# Altium schematic sheet SolarPowerBase_Sheet_1.SchDoc
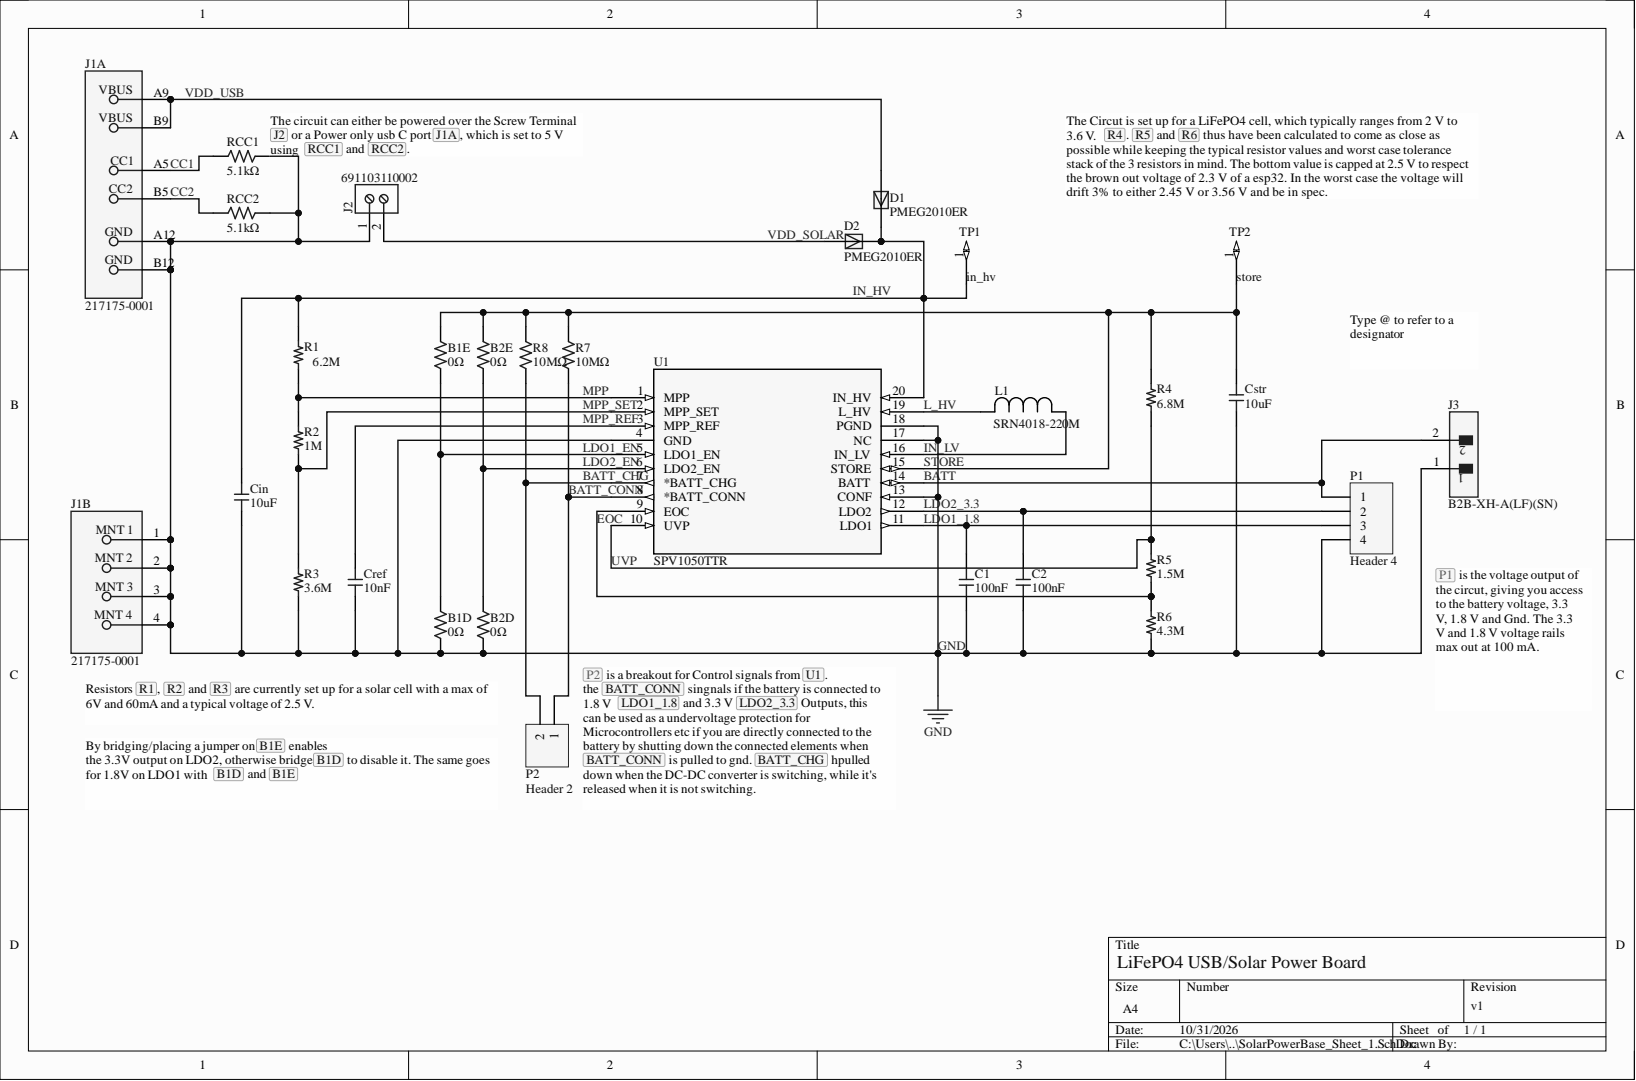
[diagram p0: SolarPowerBase_Sheet_1.SchDoc]

## Parts
B1D  Resistance: 0Ω  [RES-0402]  (hidden comment: 0402WGF0000TCE)
B1E  Resistance: 0Ω  [RES-0402]  (hidden comment: 0402WGF0000TCE)
B2D  Resistance: 0Ω  [RES-0402]  (hidden comment: 0402WGF0000TCE)
B2E  Resistance: 0Ω  [RES-0402]  (hidden comment: 0402WGF0000TCE)
C1  Capacitance: 100nF  [CAP-0402]  (hidden comment: CL05B104KB54PNC)
C2  Capacitance: 100nF  [CAP-0402]  (hidden comment: CL05B104KB54PNC)
Cin  Capacitance: 10uF  [CAP-0603]  (hidden comment: CL10A106KP8NNNC)
Cref  Capacitance: 10nF  [CAP-0402]  (hidden comment: CL05B103KB5NNNC)
Cstr  Capacitance: 10uF  [CAP-0603]  (hidden comment: CL10A106KP8NNNC)
D1  PMEG2010ER  [SODFL3517X110N]
D2  PMEG2010ER  [SODFL3517X110N]
J1  217175-0001  [FP-217175-0001-MFG]  (parts A,B)
J2  691103110002  [691103110002]
J3  B2B-XH-A(LF)(SN)  [FP-B2B-XH-A_LF_SN-MFG]
L1  SRN4018-220M  [IND_BOURNS_SRN4018]
P1  Header 4  [HDR1X4]
P2  Header 2  [HDR1X2]
R1  6.2M  [RES-0805-HS]
R2  1M  [RES-0805-HS]
R3  value 3.6M  [RES-0805-HS]  (hidden comment: 2.7k)
R4  6.8M  [RES-0805-HS]
R5  1.5M  [RES-0805-HS]
R6  4.3M  [RES-0805-HS]
R7  Resistance: 10MΩ  [RES-0603]  (hidden comment: 0603WAF1005T5E)
R8  Resistance: 10MΩ  [RES-0603]  (hidden comment: 0603WAF1005T5E)
RCC1  Resistance: 5.1kΩ  [RES-0402]  (hidden comment: 0402WGF5101TCE)
RCC2  Resistance: 5.1kΩ  [RES-0402]  (hidden comment: 0402WGF5101TCE)
TP1  in_hv  [Pogopin_pad_female_2mm]  (no BOM)
TP2  store  [Pogopin_pad_female_2mm]  (no BOM)
U1  SPV1050TTR  [VFQFPN20_3X3_STM]

## Nets
BATT: J3.2 P1.1 U1.14(BATT)
BATT_CHG: P2.2 R8.1 U1.7(*BATT_CHG)
BATT_CONN: P2.1 R7.1 U1.8(*BATT_CONN)
CC1: J1.A5 RCC1.1
CC2: J1.B5 RCC2.1
EOC: R5.1 R6.2 U1.9(EOC)
GND: B1D.1 B2D.1 C1.1 C2.1 Cin.1 Cref.1 Cstr.1 J1.1 J1.2 J1.3 J1.4 J1.A12 J1.B12 J2.1 J3.1 P1.4 R3.1 R6.1 RCC1.2 RCC2.2 U1.4(GND) U1.13(CONF) U1.17(NC) U1.18(PGND)
IN_HV: Cin.2 D1.C D2.C R1.2 TP1.1 U1.20(IN_HV)
IN_LV: L1.1 U1.16(IN_LV)
L_HV: L1.2 U1.19(L_HV)
LDO1_1.8: C1.2 P1.3 U1.11(LDO1)
LDO1_EN: B1D.2 B1E.1 U1.5(LDO1_EN)
LDO2_3.3: C2.2 P1.2 U1.12(LDO2)
LDO2_EN: B2D.2 B2E.1 U1.6(LDO2_EN)
MPP: R1.1 R2.2 U1.1(MPP)
MPP_REF: Cref.2 U1.3(MPP_REF)
MPP_SET: R2.1 R3.2 U1.2(MPP_SET)
STORE: B1E.2 B2E.2 Cstr.2 R4.2 R7.2 R8.2 TP2.1 U1.15(STORE)
UVP: R4.1 R5.2 U1.10(UVP)
VDD_SOLAR: D2.A J2.2
VDD_USB: D1.A J1.A9 J1.B9

## Library data of the parts
B1D B1E B2D B2E = 0402WGF0000TCE: Resistor, 0Ω, ±1%, 62.5mW, 50V, ±800ppm/℃, -55℃~+155℃, SMD 0402; Manufacturer: Uniroyal; Category: Resistors; Component Kind: Standard; ComponentLink1Description: Datasheet; ComponentLink1URL: https://wmsc.lcsc.com/wmsc/upload/file/pdf/v2/lcsc/2206010216_UNI-ROYAL-Uniroyal-Elec-0402WGF0000TCE_C17168.pdf; ComponentLink2Description: JLCPCB Part URL; ComponentLink2URL: https://jlcpcb.com/partdetail/C17168; JLCPCB Basic Part: Yes; JLCPCB Part Number: C17168; Library Name: jlcpcb_2025_04_resistors_basic.schlib; Manufacturer Part Number: 0402WGF0000TCE; Package Size: 0402; Power Rating: 62.5mW; Supplier 1: LCSC; Supplier Part Number 1: C17168; Temperature Coefficient: ±800ppm/℃; Temperature Rating: -55℃~+155℃; Tolerance: ±1%; Voltage Rating: 50V
C1 C2 = CL05B104KB54PNC: Capacitor, Ceramic (MLCC), 100nF, ±10%, 50V, X7R, ±10%, SMD 0402; Manufacturer: Samsung Electro-Mechanics; Capacitance @ 1.2V DC Bias: 105.639nF; Capacitance @ 1.5V DC Bias: 105.528nF; Capacitance @ 1.8V DC Bias: 105.317nF; Capacitance @ 1.35V DC Bias: 105.594nF; Capacitance @ 1V DC Bias: 105.687nF; Capacitance @ 2.5V DC Bias: 104.216nF; Capacitance @ 3.3V DC Bias: 102.385nF; Capacitance @ 3.7V DC Bias: 101.236nF; Capacitance @ 4.2V DC Bias: 99.650nF; Capacitance @ 5V DC Bias: 96.918nF; Capacitance @ 9V DC Bias: 81.073nF; Capacitance @ 12V DC Bias: 69.786nF; Capacitance @ 15V DC Bias: 59.475nF; Capacitance @ 24V DC Bias: 38.112nF; Capacitance @ 36V DC Bias: 23.027nF; Capacitance @ 48V DC Bias: 16.035nF; Impedance (0V Bias) @ 1GHz: 0.87Ω; Impedance (0V Bias) @ 1kHz: 1.7E+03Ω; Impedance (0V Bias) @ 1MHz: 1.84Ω; Impedance (0V Bias) @ 2GHz: 2Ω; Impedance (0V Bias) @ 5GHz: 3.96Ω; Impedance (0V Bias) @ 5kHz: 346Ω; Impedance (0V Bias) @ 5MHz: 0.366Ω; Impedance (0V Bias) @ 10kHz: 175Ω; Impedance (0V Bias) @ 10MHz: 0.172Ω; Impedance (0V Bias) @ 50kHz: 35.5Ω; Impedance (0V Bias) @ 50MHz: 0.0626Ω; Impedance (0V Bias) @ 100Hz: 1.66E+04Ω; Impedance (0V Bias) @ 100kHz: 17.9Ω; Impedance (0V Bias) @ 100MHz: 0.128Ω; Impedance (0V Bias) @ 500Hz: 3.38E+03Ω; Impedance (0V Bias) @ 500kHz: 3.64Ω; Impedance (0V Bias) @ 500MHz: 0.487Ω; Temperature Rating: -55°C ~ 125°C; Category: Capacitors - MLCC; Component Kind: Standard; ComponentLink1Description: Datasheet; ComponentLink1URL: https://wmsc.lcsc.com/wmsc/upload/file/pdf/v2/lcsc/2304140030_Samsung-Electro-Mechanics-CL05B104KB54PNC_C307331.pdf; ComponentLink2Description: JLCPCB Part URL; ComponentLink2URL: https://jlcpcb.com/partdetail/C307331; ComponentLink3Description: LCSC Part URL; ComponentLink3URL: https://lcsc.com/product-detail/Multilayer-Ceramic-Capacitors-MLCC---SMD-SMT_Samsung-Electro-Mechanics-Samsung-Electro-Mechanics-CL05B104KB54PNC_C307331.html; ComponentLink4Description: Samsung Component Library; ComponentLink4URL: https://weblib.samsungsem.com/mlcc/mlcc-ec.do?partNumber=CL05B104KB54PN; JLCPCB Basic Part: Yes; JLCPCB Part Number: C307331; Library Name: jlcpcb_2025_04_capacitors_basic.schlib; Manufacturer Part Number: CL05B104KB54PNC; Package Size: 0402; RoHS: Yes; Supplier 1: LCSC; Supplier Part Number 1: C307331; Temperature Coefficient: X7R; Tolerance: ±10%; Voltage Rating: 50V
Cin Cstr = CL10A106KP8NNNC: Capacitor, Ceramic (MLCC), 10uF, ±10%, 10V, X5R, ±10%, SMD 0603; Manufacturer: Samsung Electro-Mechanics; Capacitance @ 1.2V DC Bias: 8.084uF; Capacitance @ 1.5V DC Bias: 7.497uF; Capacitance @ 1.8V DC Bias: 6.915uF; Capacitance @ 1.35V DC Bias: 7.793uF; Capacitance @ 1V DC Bias: 8.451uF; Capacitance @ 2.5V DC Bias: 5.691uF; Capacitance @ 3.3V DC Bias: 4.464uF; Capacitance @ 3.7V DC Bias: 3.996uF; Capacitance @ 4.2V DC Bias: 3.516uF; Capacitance @ 5V DC Bias: 2.897uF; Capacitance @ 9V DC Bias: 1.372uF; Impedance (0V Bias) @ 1GHz: 0.788Ω; Impedance (0V Bias) @ 1kHz: 22.6Ω; Impedance (0V Bias) @ 1MHz: 0.0217Ω; Impedance (0V Bias) @ 2GHz: 1.8Ω; Impedance (0V Bias) @ 5GHz: 3.33Ω; Impedance (0V Bias) @ 5kHz: 4.64Ω; Impedance (0V Bias) @ 5MHz: 0.0144Ω; Impedance (0V Bias) @ 10kHz: 2.35Ω; Impedance (0V Bias) @ 10MHz: 0.0227Ω; Impedance (0V Bias) @ 50kHz: 0.481Ω; Impedance (0V Bias) @ 50MHz: 0.0729Ω; Impedance (0V Bias) @ 100Hz: 217Ω; Impedance (0V Bias) @ 100kHz: 0.243Ω; Impedance (0V Bias) @ 100MHz: 0.123Ω; Impedance (0V Bias) @ 500Hz: 44.6Ω; Impedance (0V Bias) @ 500kHz: 0.0484Ω; Impedance (0V Bias) @ 500MHz: 0.439Ω; Impedance (10V Bias) @ 1GHz: 0.786Ω; Impedance (10V Bias) @ 1kHz: 162Ω; Impedance (10V Bias) @ 1MHz: 0.161Ω; Impedance (10V Bias) @ 2GHz: 1.77Ω; Impedance (10V Bias) @ 5GHz: 3.28Ω; Impedance (10V Bias) @ 5kHz: 32.7Ω; Impedance (10V Bias) @ 5MHz: 0.0215Ω; Impedance (10V Bias) @ 10kHz: 16.4Ω; Impedance (10V Bias) @ 10MHz: 0.0228Ω; Impedance (10V Bias) @ 50kHz: 3.3Ω; Impedance (10V Bias) @ 50MHz: 0.0759Ω; Impedance (10V Bias) @ 100Hz: 1.6E+03Ω; Impedance (10V Bias) @ 100kHz: 1.66Ω; Impedance (10V Bias) @ 500Hz: 323Ω; Impedance (10V Bias) @ 500kHz: 0.331Ω; Impedance (10V Bias) @ 500MHz: 0.443Ω; Temperature Rating: -55°C ~ 85°C; Category: Capacitors - MLCC; Component Kind: Standard; ComponentLink1Description: Datasheet; ComponentLink1URL: https://wmsc.lcsc.com/wmsc/upload/file/pdf/v2/lcsc/2304140030_Samsung-Electro-Mechanics-CL10A106KP8NNNC_C19702.pdf; ComponentLink2Description: JLCPCB Part URL; ComponentLink2URL: https://jlcpcb.com/partdetail/C19702; ComponentLink3Description: LCSC Part URL; ComponentLink3URL: https://lcsc.com/product-detail/Multilayer-Ceramic-Capacitors-MLCC---SMD-SMT_Samsung-Electro-Mechanics-Samsung-Electro-Mechanics-CL10A106KP8NNNC_C19702.html; ComponentLink4Description: Samsung Component Library; ComponentLink4URL: https://weblib.samsungsem.com/mlcc/mlcc-ec.do?partNumber=CL10A106KP8NNN; Impedance (10V Bias) @ 100MHz: 0.123Ω; JLCPCB Basic Part: Yes; JLCPCB Part Number: C19702; Library Name: jlcpcb_2025_04_capacitors_basic.schlib; Manufacturer Part Number: CL10A106KP8NNNC; Package Size: 0603; RoHS: Yes; Supplier 1: LCSC; Supplier Part Number 1: C19702; Temperature Coefficient: X5R; Tolerance: ±10%; Voltage Rating: 10V
Cref = CL05B103KB5NNNC: Capacitor, Ceramic (MLCC), 10nF, ±10%, 50V, X7R, ±10%, SMD 0402; Manufacturer: Samsung Electro-Mechanics; Capacitance @ 1.2V DC Bias: 10.434nF; Capacitance @ 1.5V DC Bias: 10.455nF; Capacitance @ 1.8V DC Bias: 10.480nF; Capacitance @ 1.35V DC Bias: 10.444nF; Capacitance @ 1V DC Bias: 10.426nF; Capacitance @ 2.5V DC Bias: 10.514nF; Capacitance @ 3.3V DC Bias: 10.519nF; Capacitance @ 3.7V DC Bias: 10.504nF; Capacitance @ 4.2V DC Bias: 10.473nF; Capacitance @ 5V DC Bias: 10.402nF; Capacitance @ 9V DC Bias: 10.052nF; Capacitance @ 12V DC Bias: 9.639nF; Capacitance @ 15V DC Bias: 9.187nF; Capacitance @ 24V DC Bias: 7.606nF; Capacitance @ 36V DC Bias: 5.499nF; Capacitance @ 48V DC Bias: 3.591nF; Impedance (0V Bias) @ 1GHz: 1.42Ω; Impedance (0V Bias) @ 1kHz: 1.61E+04Ω; Impedance (0V Bias) @ 1MHz: 17.3Ω; Impedance (0V Bias) @ 2GHz: 3.22Ω; Impedance (0V Bias) @ 5GHz: 6.48Ω; Impedance (0V Bias) @ 5kHz: 3.27E+03Ω; Impedance (0V Bias) @ 5MHz: 3.52Ω; Impedance (0V Bias) @ 10kHz: 1.65E+03Ω; Impedance (0V Bias) @ 10MHz: 1.77Ω; Impedance (0V Bias) @ 50kHz: 335Ω; Impedance (0V Bias) @ 50MHz: 0.285Ω; Impedance (0V Bias) @ 100Hz: 1.57E+05Ω; Impedance (0V Bias) @ 100kHz: 169Ω; Impedance (0V Bias) @ 100MHz: 0.0835Ω; Impedance (0V Bias) @ 500Hz: 3.19E+04Ω; Impedance (0V Bias) @ 500kHz: 34.3Ω; Impedance (0V Bias) @ 500MHz: 0.751Ω; Temperature Rating: -55°C ~ 125°C; Category: Capacitors - MLCC; Component Kind: Standard; ComponentLink1Description: Datasheet; ComponentLink1URL: https://wmsc.lcsc.com/wmsc/upload/file/pdf/v2/lcsc/2304140030_Samsung-Electro-Mechanics-CL05B103KB5NNNC_C15195.pdf; ComponentLink2Description: JLCPCB Part URL; ComponentLink2URL: https://jlcpcb.com/partdetail/C15195; ComponentLink3Description: LCSC Part URL; ComponentLink3URL: https://lcsc.com/product-detail/Multilayer-Ceramic-Capacitors-MLCC---SMD-SMT_Samsung-Electro-Mechanics-Samsung-Electro-Mechanics-CL05B103KB5NNNC_C15195.html; ComponentLink4Description: Samsung Component Library; ComponentLink4URL: https://weblib.samsungsem.com/mlcc/mlcc-ec.do?partNumber=CL05B103KB5NNN; JLCPCB Basic Part: Yes; JLCPCB Part Number: C15195; Library Name: jlcpcb_2025_04_capacitors_basic.schlib; Manufacturer Part Number: CL05B103KB5NNNC; Package Size: 0402; RoHS: Yes; Supplier 1: LCSC; Supplier Part Number 1: C15195; Temperature Coefficient: X7R; Tolerance: ±10%; Voltage Rating: 50V
D1 D2 = PMEG2010ER: Rectifier Diode Schottky 1A Automotive 2-Pin SOD-123W T/R; MF: NXP Semiconductors; Check_prices: https://www.snapeda.com/parts/PMEG2010ER/NXP+Semiconductors/view-part/?ref=eda; Component Kind: Standard; Library Name: PMEG2010ER.IntLib; MP: PMEG2010ER; Package: SOD-123 Nexperia USA; SnapEDA_Link: https://www.snapeda.com/parts/PMEG2010ER/NXP+Semiconductors/view-part/?ref=snap
J1 = CMP-04767-000026-1: CONN RCP USB2.0 C 6+18P SMD RA; Component Kind: Standard; Library Name: Altium Content Vault
J2 = CMP-1502-03254-3: 1031 Series 3.5MM Pitch Straight, Pcb Terminal Block, Through Hole, 2 Way; Current: 10A; Number of Contacts: 2; Number of Levels: 1; Pitch: 3.5052mm; Plating: Steel; Stripping Length: -6mm; Voltage: 125V; Wire Gauge (Max): 26AWG; Wire Gauge (Min): 16AWG; Color: Blue; Component Kind: Standard; Contact Material: Copper, Nickel, Tin, Zinc; Contact Plating: Tin; Current Rating: 10A; Height: 8.5mm; Housing Material: Nylon; Lead Pitch: 3.5mm; Length: 7mm; Library Name: Altium Content Vault; Max Operating Temperature: 105°C; Min Operating Temperature: -40°C; Mount: Through Hole; Number of Pins: 2; Number of Positions Per Level: 2; Number of Rows: 1; Packaging: Box; RoHS: Compliant; Termination: Screw; Voltage Rating: 125V; Width: 8mm; Wire/Cable Gauge: 26AWG
J3 = CMP-2000-05888-3: CONN HEADER VERT 2POS 2.5MM; Connector Type: Male Pin; Contact Resistance: 20mR; Current Rating: 3A; Insulation Resistance: 1GR; Max Voltage Rating (AC): 250V; Number of Contacts: 2; Wire/Cable Gauge: 22AWG; Color: Natural; Component Kind: Standard; Contact Material: Brass; Contact Plating: Tin; Contact Type: Male Pin; Depth: 5.75mm; Fastening Type: Detent; Housing Color: White; Housing Material: Polyamide; Lead Free: Lead Free; Lead Pitch: 2.5mm; Length: 7.4mm; Library Name: Altium Content Vault; Max Current Rating: 3A; Max Operating Temperature: 85°C; Max Voltage Rating (DC): 250V; Min Operating Temperature: -25°C; Mount: Through Hole; Number of Positions: 2; Number of Rows: 1; Orientation: Straight; Packaging: Bulk; Pitch: 2.5mm; REACH SVHC: No SVHC; Series: XH; Termination: Solder; Voltage Rating: 250V; Voltage Rating (AC): 250V
L1 = SRN4018-220M: No Description Available; Manufacturer_Name: Bourns Electronics; Component Kind: Standard; Library Name: SRN4018-220M.SchLib; Manufacturer_Part_Number: SRN4018-220M; Copyright: Copyright (C) 2026 Ultra Librarian. All rights reserved.
P1 = Header 4: Header, 4-Pin; Component Kind: Standard; LatestRevisionDate: 17-Jul-2002; LatestRevisionNote: Re-released for DXP Platform.; Library Name: Miscellaneous Connectors.IntLib; Signal Integrity: Connector; Publisher: Altium Limited
P2 = Header 2: Header, 2-Pin; Component Kind: Standard; LatestRevisionDate: 17-Jul-2002; LatestRevisionNote: Re-released for DXP Platform.; Library Name: Miscellaneous Connectors.IntLib; Signal Integrity: Connector; Publisher: Altium Limited
R1 = CRCW08056M20FKEA: RES SMD 6.2M OHM 1% 1/8W 0805; Manufacturer: Vishay Dale; Database Table Name: Resistors - Surface Mount - 0805; Power (Watts): 0.125W, 1/8W; Resistance: 6.2 MOhms; Temperature Coefficient: ±100ppm/°C; Component Kind: Standard; ComponentLink1Description: Datasheet; ComponentLink1URL: http://www.vishay.com/docs/20035/dcrcwe3.pdf; ComponentLink2Description: DigiKey Link; ComponentLink2URL: https://www.digikey.com/en/products/detail/vishay-dale/CRCW08056M20FKEA/1176107; Composition: Thick Film; Device Package: 0805 (2012 Metric); Features: Automotive AEC-Q200; Height - Seated (Max): 0.020" (0.50mm); LastUpdated: 05/04/2026 08:09:23 pm; Library Name: Celestial Altium Library - altium_library.DbLib; Lifecycle Status: Active; Minimum Order: 1; Number of Terminations: 2; Operating Temperature: -55°C ~ 155°C; Package / Case: 0805 (2012 Metric); Packaging: TapeAndReel; Part Number: CRCW08056M20FKEA; Part Status: Active; PartId: 49587; Price: 0.01; Ratings: AEC-Q200; Series: D/CRCW e3; Size / Dimension: 0.079" L x 0.049" W (2.00mm x 1.25mm); Supplier 1: DigiKey; Supplier Device Package: 0805; Supplier Part Number 1: 541-6.20MCCT-ND; Tolerance: ±1%; Value: 6.2M
R2 = CRCW08051M00FKEA: RES SMD 1M OHM 1% 1/8W 0805; Manufacturer: Vishay Dale; Database Table Name: Resistors - Surface Mount - 0805; Power (Watts): 0.125W, 1/8W; Resistance: 1 MOhms; Temperature Coefficient: ±100ppm/°C; Component Kind: Standard; ComponentLink1Description: Datasheet; ComponentLink1URL: http://www.vishay.com/docs/20035/dcrcwe3.pdf; ComponentLink2Description: DigiKey Link; ComponentLink2URL: https://www.digikey.com/en/products/detail/vishay-dale/CRCW08051M00FKEA/1176016; Composition: Thick Film; Device Package: 0805 (2012 Metric); Features: Automotive AEC-Q200; Height - Seated (Max): 0.020" (0.50mm); LastUpdated: 05/04/2026 08:11:05 pm; Library Name: Celestial Altium Library - altium_library.DbLib; Lifecycle Status: Active; Minimum Order: 1; Number of Terminations: 2; Operating Temperature: -55°C ~ 155°C; Package / Case: 0805 (2012 Metric); Packaging: TapeAndReel; Part Number: CRCW08051M00FKEA; Part Status: Active; PartId: 32627; Price: 0.01; Ratings: AEC-Q200; Series: D/CRCW e3; Size / Dimension: 0.079" L x 0.049" W (2.00mm x 1.25mm); Supplier 1: DigiKey; Supplier Device Package: 0805; Supplier Part Number 1: 541-1.00MCCT-ND; Tolerance: ±1%; Value: 1M
R3 = CRCW08053M60FKEA: RES SMD 3.6M OHM 1% 1/4W 0805; Manufacturer: Vishay Dale; Database Table Name: Resistors - Surface Mount - 0805; Power (Watts): 0.25W, 1/4W; Resistance: 3.6 MOhms; Temperature Coefficient: ±100ppm/°C; Component Kind: Standard; ComponentLink1Description: Datasheet; ComponentLink1URL: http://www.vishay.com/docs/20035/dcrcwe3.pdf; ComponentLink2Description: DigiKey Link; ComponentLink2URL: https://www.digikey.com/en/products/detail/vishay-dale/CRCW08053M60FKEA/1176078; Composition: Thick Film; Device Package: 0805 (2012 Metric); Features: Automotive AEC-Q200; Height - Seated (Max): 0.020" (0.50mm); LastUpdated: 05/04/2026 08:09:28 pm; Library Name: Celestial Altium Library - altium_library.DbLib; Lifecycle Status: Active; Minimum Order: 1; Number of Terminations: 2; Operating Temperature: -55°C ~ 155°C; Package / Case: 0805 (2012 Metric); Packaging: TapeAndReel; Part Number: CRCW08053M60FKEA; Part Status: Active; PartId: 49215; Price: 0.01; Ratings: AEC-Q200; Series: D/CRCW e3; Size / Dimension: 0.079" L x 0.049" W (2.00mm x 1.25mm); Supplier 1: DigiKey; Supplier Device Package: 0805; Supplier Part Number 1: 541-3.60MCCT-ND; Tolerance: ±1%
R4 = CRCW08056M80FKEA: RES SMD 6.8M OHM 1% 1/4W 0805; Manufacturer: Vishay Dale; Database Table Name: Resistors - Surface Mount - 0805; Power (Watts): 0.25W, 1/4W; Resistance: 6.8 MOhms; Temperature Coefficient: ±100ppm/°C; Component Kind: Standard; ComponentLink1Description: Datasheet; ComponentLink1URL: http://www.vishay.com/docs/20035/dcrcwe3.pdf; ComponentLink2Description: DigiKey Link; ComponentLink2URL: https://www.digikey.com/en/products/detail/vishay-dale/CRCW08056M80FKEA/1176111; Composition: Thick Film; Device Package: 0805 (2012 Metric); Features: Automotive AEC-Q200; Height - Seated (Max): 0.020" (0.50mm); LastUpdated: 05/04/2026 08:10:56 pm; Library Name: Celestial Altium Library - altium_library.DbLib; Lifecycle Status: Active; Minimum Order: 1; Number of Terminations: 2; Operating Temperature: -55°C ~ 155°C; Package / Case: 0805 (2012 Metric); Packaging: TapeAndReel; Part Number: CRCW08056M80FKEA; Part Status: Active; PartId: 34638; Price: 0.01; Ratings: AEC-Q200; Series: D/CRCW e3; Size / Dimension: 0.079" L x 0.049" W (2.00mm x 1.25mm); Supplier 1: DigiKey; Supplier Device Package: 0805; Supplier Part Number 1: 541-6.80MCCT-ND; Tolerance: ±1%; Value: 6.8M
R5 = CRCW08051M50FKEA: RES SMD 1.5M OHM 1% 1/4W 0805; Manufacturer: Vishay Dale; Database Table Name: Resistors - Surface Mount - 0805; Power (Watts): 0.25W, 1/4W; Resistance: 1.5 MOhms; Temperature Coefficient: ±100ppm/°C; Component Kind: Standard; ComponentLink1Description: Datasheet; ComponentLink1URL: http://www.vishay.com/docs/20035/dcrcwe3.pdf; ComponentLink2Description: DigiKey Link; ComponentLink2URL: https://www.digikey.com/en/products/detail/vishay-dale/CRCW08051M50FKEA/1176034; Composition: Thick Film; Device Package: 0805 (2012 Metric); Features: Automotive AEC-Q200; Height - Seated (Max): 0.020" (0.50mm); LastUpdated: 05/04/2026 08:11:04 pm; Library Name: Celestial Altium Library - altium_library.DbLib; Lifecycle Status: Active; Minimum Order: 1; Number of Terminations: 2; Operating Temperature: -55°C ~ 155°C; Package / Case: 0805 (2012 Metric); Packaging: TapeAndReel; Part Number: CRCW08051M50FKEA; Part Status: Active; PartId: 32740; Price: 0.01; Ratings: AEC-Q200; Series: D/CRCW e3; Size / Dimension: 0.079" L x 0.049" W (2.00mm x 1.25mm); Supplier 1: DigiKey; Supplier Device Package: 0805; Supplier Part Number 1: 541-1.50MCCT-ND; Tolerance: ±1%; Value: 1.5M
R6 = CRCW08054M30FKEA: RES SMD 4.3M OHM 1% 1/4W 0805; Manufacturer: Vishay Dale; Database Table Name: Resistors - Surface Mount - 0805; Power (Watts): 0.25W, 1/4W; Resistance: 4.3 MOhms; Temperature Coefficient: ±100ppm/°C; Component Kind: Standard; ComponentLink1Description: Datasheet; ComponentLink1URL: http://www.vishay.com/docs/20035/dcrcwe3.pdf; ComponentLink2Description: DigiKey Link; ComponentLink2URL: https://www.digikey.com/en/products/detail/vishay-dale/CRCW08054M30FKEA/1176087; Composition: Thick Film; Device Package: 0805 (2012 Metric); Features: Automotive AEC-Q200; Height - Seated (Max): 0.020" (0.50mm); LastUpdated: 05/04/2026 08:09:29 pm; Library Name: Celestial Altium Library - altium_library.DbLib; Lifecycle Status: Active; Minimum Order: 1; Number of Terminations: 2; Operating Temperature: -55°C ~ 155°C; Package / Case: 0805 (2012 Metric); Packaging: TapeAndReel; Part Number: CRCW08054M30FKEA; Part Status: Active; PartId: 49145; Price: 0.01; Ratings: AEC-Q200; Series: D/CRCW e3; Size / Dimension: 0.079" L x 0.049" W (2.00mm x 1.25mm); Supplier 1: DigiKey; Supplier Device Package: 0805; Supplier Part Number 1: 541-4.30MCCT-ND; Tolerance: ±1%; Value: 4.3M
R7 R8 = 0603WAF1005T5E: Resistor, 10MΩ, ±1%, 100mW, 75V, ±100ppm/℃, -55℃~+155℃, SMD 0603; Manufacturer: Uniroyal; Category: Resistors; Component Kind: Standard; ComponentLink1Description: Datasheet; ComponentLink1URL: https://wmsc.lcsc.com/wmsc/upload/file/pdf/v2/lcsc/2206010216_UNI-ROYAL-Uniroyal-Elec-0603WAF1005T5E_C7250.pdf; ComponentLink2Description: JLCPCB Part URL; ComponentLink2URL: https://jlcpcb.com/partdetail/C7250; JLCPCB Basic Part: Yes; JLCPCB Part Number: C7250; Library Name: jlcpcb_2025_04_resistors_basic.schlib; Manufacturer Part Number: 0603WAF1005T5E; Package Size: 0603; Power Rating: 100mW; Supplier 1: LCSC; Supplier Part Number 1: C7250; Temperature Coefficient: ±100ppm/℃; Temperature Rating: -55℃~+155℃; Tolerance: ±1%; Voltage Rating: 75V
RCC1 RCC2 = 0402WGF5101TCE: Resistor, 5.1kΩ, ±1%, 62.5mW, 50V, ±100ppm/℃, -55℃~+155℃, SMD 0402; Manufacturer: Uniroyal; Category: Resistors; Component Kind: Standard; ComponentLink1Description: Datasheet; ComponentLink1URL: https://wmsc.lcsc.com/wmsc/upload/file/pdf/v2/lcsc/2206010045_UNI-ROYAL-Uniroyal-Elec-0402WGF5101TCE_C25905.pdf; ComponentLink2Description: JLCPCB Part URL; ComponentLink2URL: https://jlcpcb.com/partdetail/C25905; JLCPCB Basic Part: Yes; JLCPCB Part Number: C25905; Library Name: jlcpcb_2025_04_resistors_basic.schlib; Manufacturer Part Number: 0402WGF5101TCE; Package Size: 0402; Power Rating: 62.5mW; Supplier 1: LCSC; Supplier Part Number 1: C25905; Temperature Coefficient: ±100ppm/℃; Temperature Rating: -55℃~+155℃; Tolerance: ±1%; Voltage Rating: 50V
TP1 TP2 = PogoPinPad: Simple pad for the pogo pin to make contact with; Library Name: PogopenSchem.SchLib
U1 = SPV1050TTR: Ultra low power energy harvester and battery charger with embedded MPPT and LDOs; Manufacturer_Name: STMicroelectronics; Component Kind: Standard; Library Name: SPV1050TTR1.SchLib; Manufacturer_Part_Number: SPV1050TTR; RefDes: RefDes; Type: Type; Copyright: Copyright (C) 2026 Ultra Librarian. All rights reserved.
